# Altium schematic sheet Badge11.SchDoc
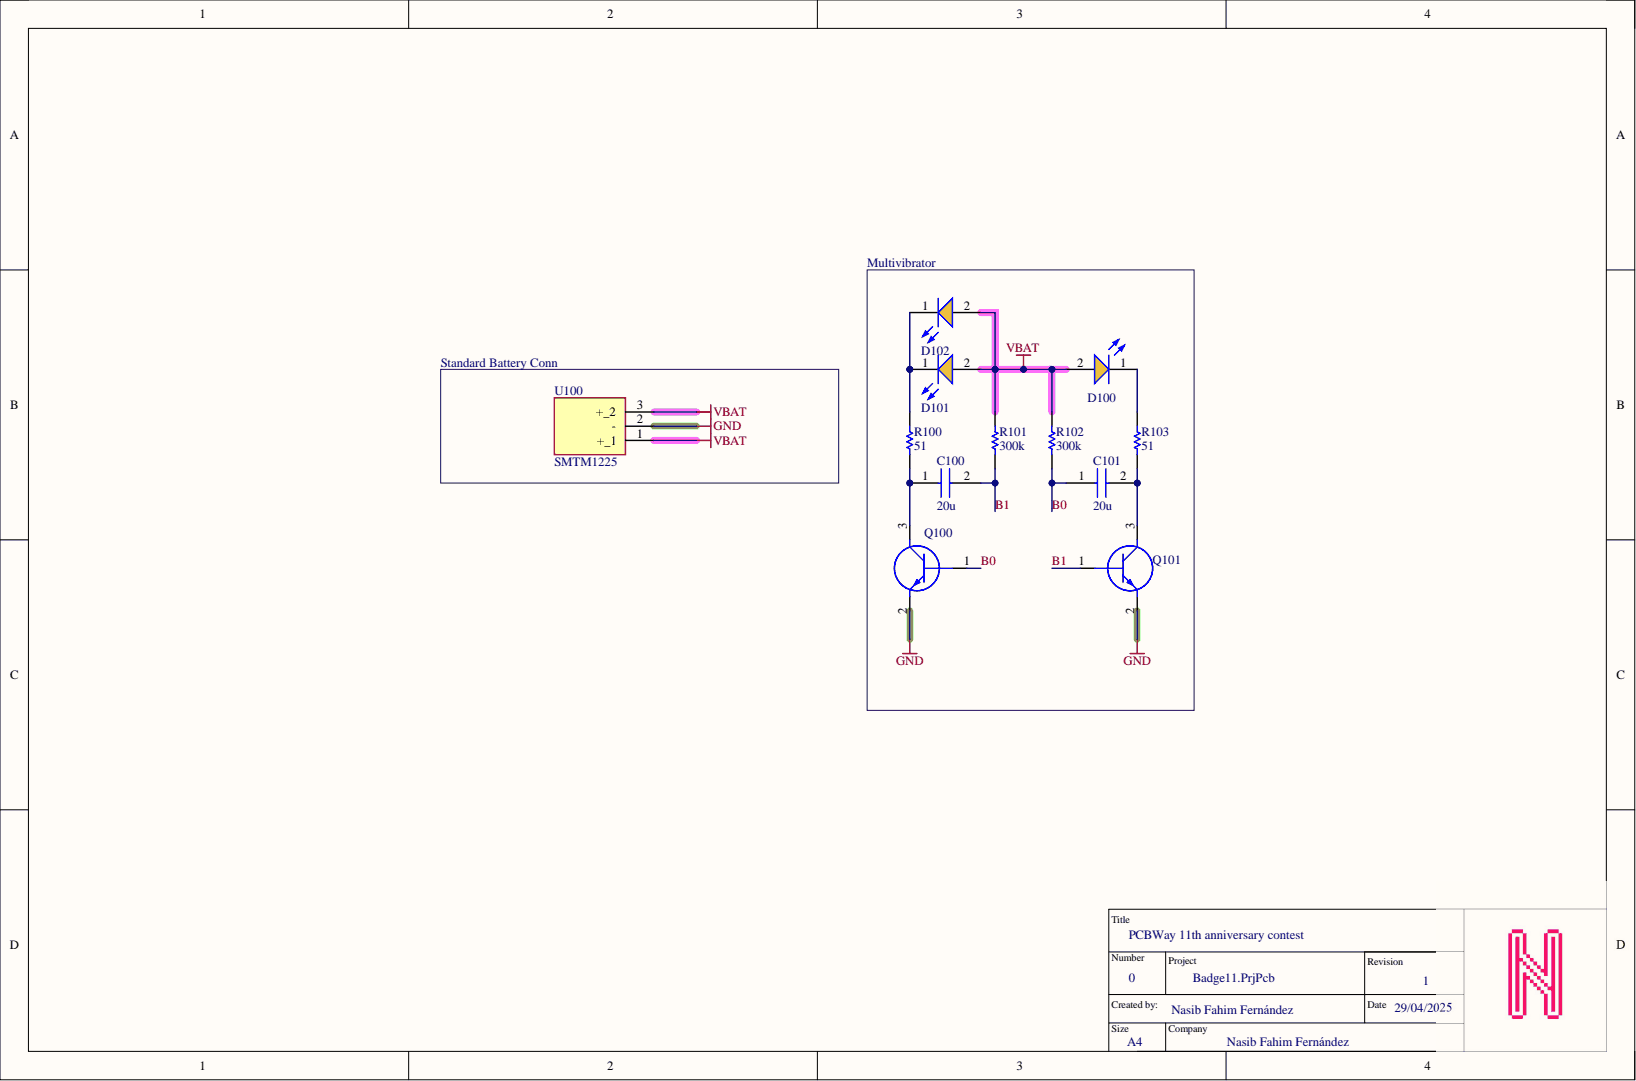
[diagram p0: Badge11.SchDoc]

## Parts
C100  20u  [GRMJN7]
C101  20u  [GRMJN7]
D100  156120AS82500  [WL-SMRW_1206_dome_reverse use]  (value not drawn)
D101  156120AS82500  [WL-SMRW_1206_dome_reverse use]  (value not drawn)
D102  156120AS82500  [WL-SMRW_1206_dome_reverse use]  (value not drawn)
Q100  MMBT2222A-G  [SOT95P240X115-3N]  (value not drawn)
Q101  MMBT2222A-G  [SOT95P240X115-3N]  (value not drawn)
R100  51  [RESC1609X50X30NL8T20]
R101  300k  [RESC1609X50X30NL8T20]
R102  300k  [RESC1609X50X30NL8T20]
R103  51  [RESC1609X50X30NL8T20]
U100  SMTM1225  [SMTM1225]

## Nets
GND: Q100.2 Q101.2 U100.2
VBAT: D100.2 D101.2 D102.2 R101.2 R102.2 U100.1 U100.3
B0: C101.1 Q100.1 R102.1
B1: C100.2 Q101.1 R101.1
NetC100_1: C100.1 Q100.3 R100.1
NetC101_2: C101.2 Q101.3 R103.1
NetD100_1: D100.1 R103.2
NetD101_1: D101.1 D102.1 R100.2

## Library data of the parts
C100 C101 = GRMJN7R61C206ME05D: 20 uF 16 VDC 20% 0704 X5R; Manufacturer: Murata Electronics; Arrow Part Number: GRMJN7R61C206ME05D; Arrow Price/Stock: https://www.arrow.com/en/products/grmjn7r61c206me05d/murata-manufacturing?region=nac; Component Kind: Standard; Datasheet Link: https://search.murata.co.jp/Ceramy/image/img/A01X/G101/ENG/GRMJN7R61C206ME05-01A.pdf; Mouser Part Number: 81-GRMJN7R61C206ME5D; Mouser Price/Stock: https://www.mouser.co.uk/ProductDetail/Murata-Electronics/GRMJN7R61C206ME05D?qs=rrS6PyfT74coUKxXgqe%2FlQ%3D%3D; Part Number: GRMJN7R61C206ME05D; Value: 20u
D100-D102 = CMP-03913-82270990-1: 156120AS82500 WL-SMRW SMT Mono-color Reverse mount Waterclear; Manufacturer: Wurth Elektronik; Dominant Wavelength: 605nm; Forward Current: 40mA; Forward Voltage: 2V; Luminous Intensity: 2.2cd; Peak Wavelength: 609nm; Viewing Angle: 20°; Component Kind: Standard; Height: 1.85mm; Length: 3.2mm; Library Name: Altium Content Vault; Max Operating Temperature: 85°C; Min Operating Temperature: -40°C; NexarPartId: 82270990; Packaging: Tape and Reel; Part Number: 156120AS82500; RoHS: Compliant; Wavelength: 605nm; Width: 1.6mm
Q100 Q101 = MMBT2222A-G: BJT BJT, SOT-23, 40V, 600mA, NPN; Manufacturer: Comchip Technology; Arrow Part Number: MMBT2222A-G; Arrow Price/Stock: https://www.arrow.com/en/products/mmbt2222a-g/comchip-technology?region=nac; Component Kind: Standard; Datasheet Link: https://datasheet.datasheetarchive.com/originals/distributors/Datasheets-7/DSA-138996.pdf; Height: 1.15mm; Mouser Part Number: 750-MMBT2222A-G; Mouser Price/Stock: https://www.mouser.co.uk/ProductDetail/Comchip-Technology/MMBT2222A-G?qs=2qJf6qQ4IOIM%2FSE9iCL8gQ%3D%3D; Part Number: MMBT2222A-G
R100 R103 = CMP-1666-00005-4: SMD 1/8W 51 OHM 5%; Manufacturer: KOA Speer; Part Number: RK73B1JTTDD510J; Component Kind: Standard; ComponentLink2Description: Datasheet; ComponentLink2URL: https://datasheet.ciiva.com/11809/0900766b80d98afc-11809037.pdf; Library Name: Altium Content Vault; Value: 51
R101 R102 = CMP-1666-00005-4: SMD 300kOhms 1/10W 0603 1%; Manufacturer: Yageo; Part Number: RC0603FR-10300KL; Component Kind: Standard; ComponentLink2Description: Datasheet; ComponentLink2URL: https://datasheet.ciiva.com/11809/0900766b80d98afc-11809037.pdf; Library Name: Altium Content Vault; Value: 300k
U100 = SMTM1225: SMT HOLDER FOR CR1225; Manufacturer: RENATA; Component Kind: Standard; Datasheet Link: https://www.renata.com/en/products/battery-holders/smtm12251/; Mouser Part Number: 614-SMTM1225; Mouser Price/Stock: https://www.mouser.co.uk/ProductDetail/Renata/SMTM1225?qs=aopNjFtpd%2Fv83efERMLOpQ%3D%3D; Part Number: SMTM1225
